# Altium schematic sheet main.SchDoc
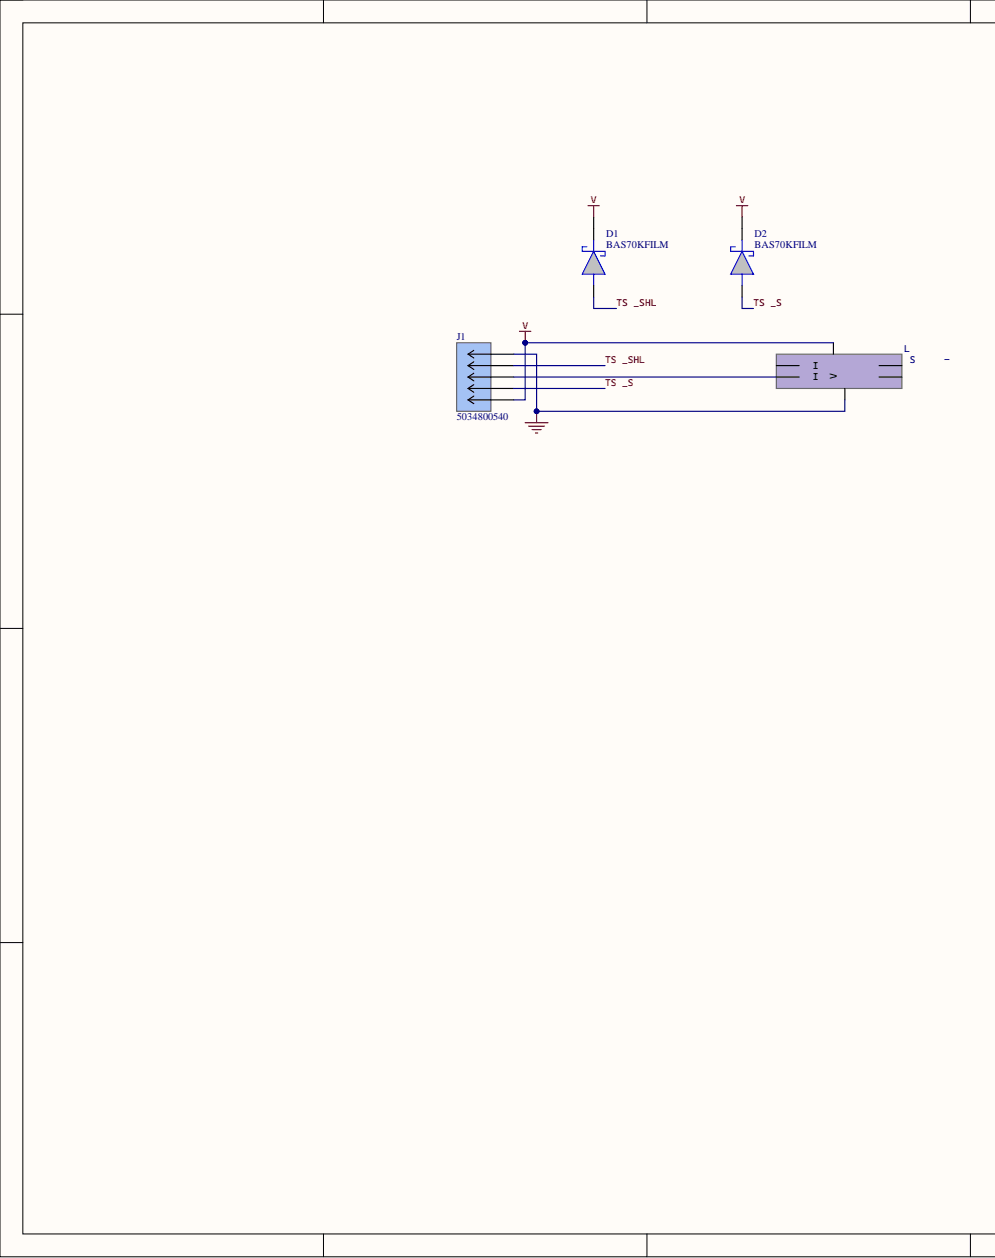
[diagram p0: main.SchDoc, left]
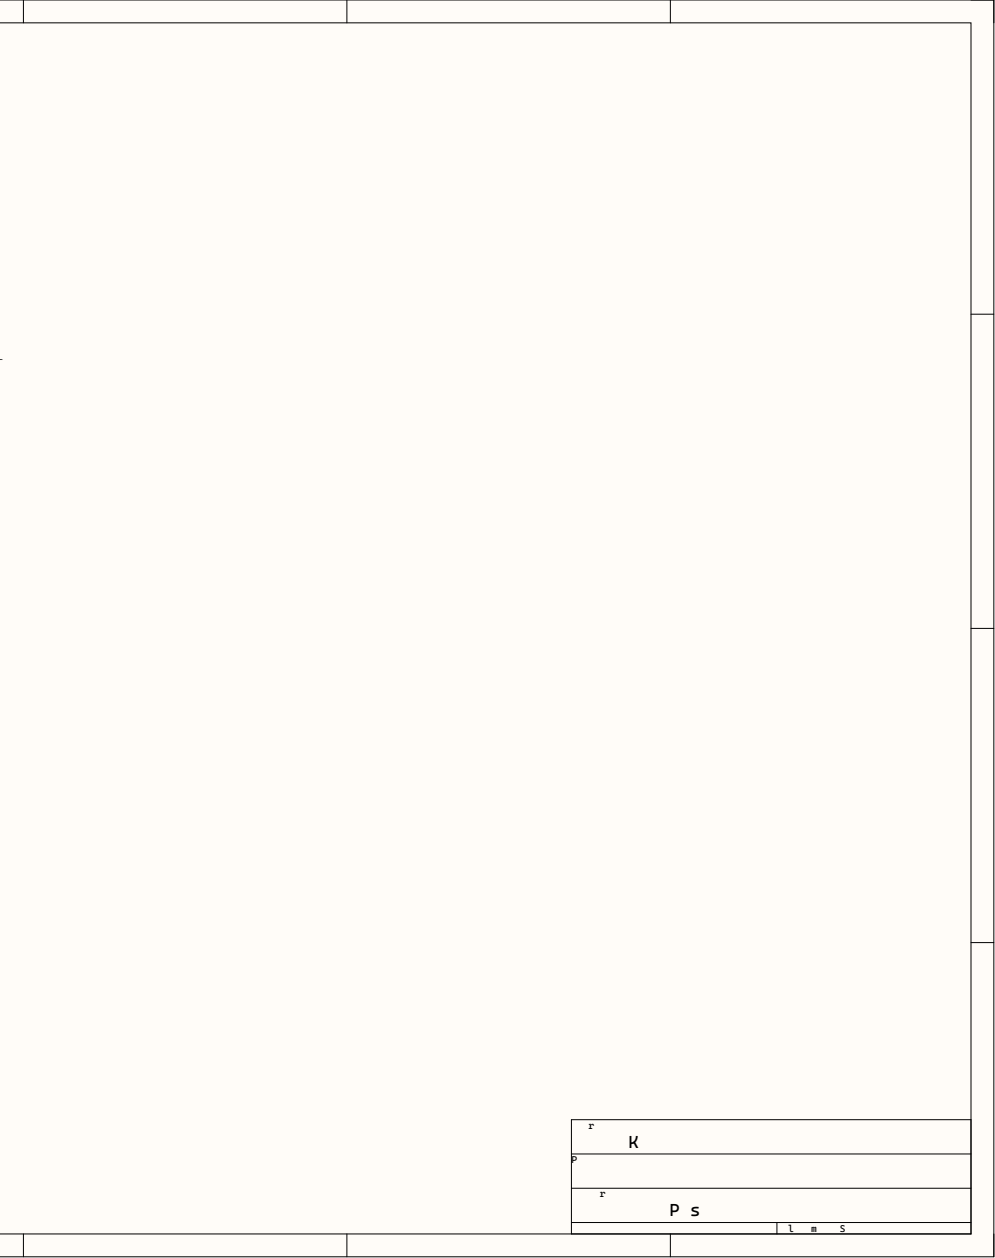
[diagram p1: main.SchDoc, right]

## Parts
D1  BAS70KFILM  [SODFL1608X70N]
D2  BAS70KFILM  [SODFL1608X70N]
J1  5034800540  [CONN_503480-0540_MOL]
LED1  WS2816A-2121  [ws2816a-2121]

## Nets
3V3: D1.1 D2.1 J1.1 LED1.3(VDD)
GND: J1.5 LED1.6(GND)
TSC_SEN: D2.2 J1.2
TSC_SHLD: D1.2 J1.4
NetJ1_3: J1.3 LED1.2(DI)
NetLED1_1: LED1.1(BI)
NetLED1_4: LED1.4(DO)
NetLED1_5: LED1.5(BO)

## Library data of the parts
D1 D2 = BAS70KFILM: STMicroelectronics BAS70KFILM, SMT Schottky Diode, 70V 70mA, 2-Pin SOD-523; Geometry.Height: 0.7mm; Arrow Part Number: BAS70KFILM; Arrow Price/Stock: https://www.arrow.com/en/products/bas70kfilm/stmicroelectronics?region=nac; Component Kind: Standard; Datasheet Link: https://www.st.com/resource/en/datasheet/bas70.pdf; Library Name: BAS70KFILM.SchLib; Manufacturer_Name: STMicroelectronics; Manufacturer_Part_Number: BAS70KFILM; Mouser Part Number: 511-BAS70KFILM; Mouser Price/Stock: https://www.mouser.co.uk/ProductDetail/STMicroelectronics/BAS70KFILM?qs=jZi1jxfVU95GtldeDT2NyQ%3D%3D
J1 = 5034800540: Easy-On FFC/FPC Connector, 0.50mm Pitch, FBH1 Series, Right-Angle, Dual Contact, 1.00mm Height, 5 Circuits, Gold over Nickel Plating; Manufacturer_Name: Molex Connector Corporation; Component Kind: Standard; Library Name: 5034800540.SchLib; Manufacturer_Part_Number: 5034800540; RefDes: RefDes; Type: Type; Copyright: Copyright (C) 2025 Ultra Librarian. All rights reserved.
LED1 = WS2816A-2121: Component Kind: Standard; Library Name: ws2816a-2121.SchLib; Manufacturer_Part_Number: WS2816A-2121
